# Altium schematic sheet 6-Latching AMS.SchDoc("6-Latching AMS")
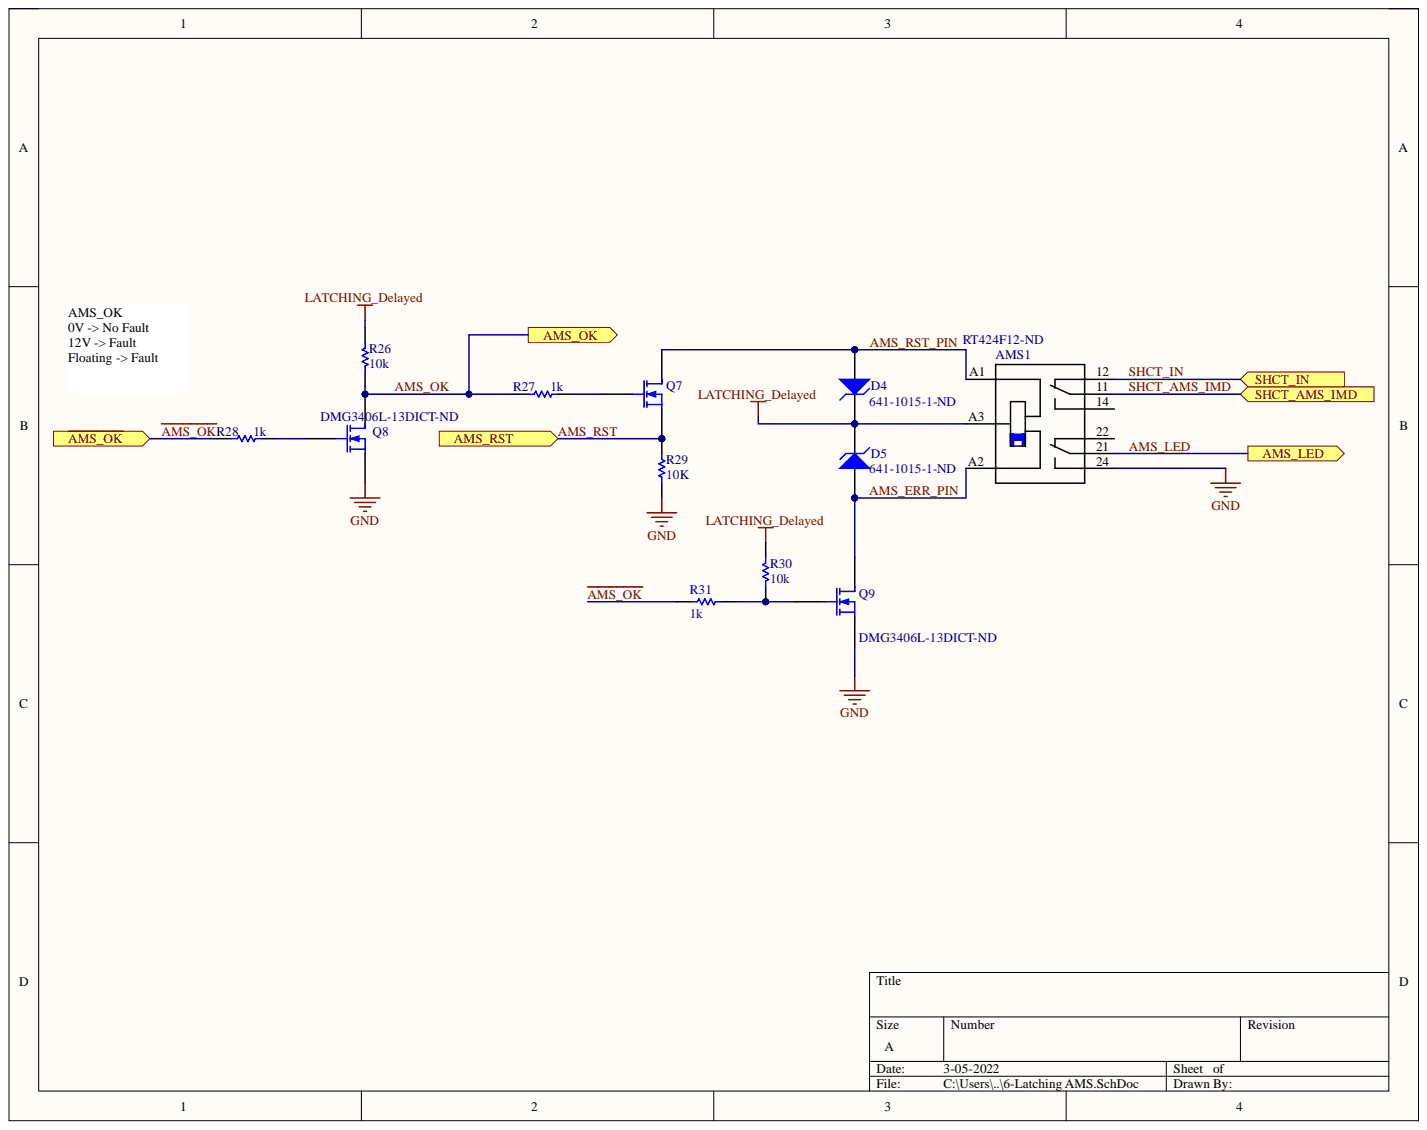
[diagram p0: 6-Latching AMS.SchDoc]

## Parts
AMS1  Supplier Part Number 1: RT424F12-ND  [TE_5-1393243-4]  (hidden comment: 5-1393243-4)
D4  Supplier Part Number 1: 641-1015-1-ND  [DO214AC]  (hidden comment: D Zener)
D5  Supplier Part Number 1: 641-1015-1-ND  [DO214AC]  (hidden comment: D Zener)
Q7  (no value)  [SOT-23_N]
Q8  Supplier Part Number 1: DMG3406L-13DICT-ND  [SOT-23_N]
Q9  Supplier Part Number 1: DMG3406L-13DICT-ND  [SOT-23_N]
R26  Resistance (Ohms): 10k  [1608[0603]]  (hidden comment: RHM1.0KDCT-ND)
R27  value 1k  [1608[0603]]  (hidden comment: Res1)
R28  value 1k  [1608[0603]]  (hidden comment: Res1)
R29  value 10K  [1608[0603]]  (hidden comment: Res1)
R30  Resistance (Ohms): 10k  [1608[0603]]  (hidden comment: RHM1.0KDCT-ND)
R31  1k  [1608[0603]]

## Ports
AMS_LED, AMS_OK, AMS_RST, SHCT_AMS_IMD, SHCT_IN, ~{AMS_OK}

## Nets
GND: AMS1.24 Q8.2 Q9.2 R29.1
LATCHING_Delayed: AMS1.A3 D4.2 D5.2 R26.1 R30.1
~{AMS_OK}: R28.1 R31.1 ; port ~{AMS_OK}
AMS_ERR_PIN: AMS1.A2 D5.1 Q9.3
AMS_LED: AMS1.21 ; port AMS_LED
AMS_OK: Q8.3 R26.2 R27.1 ; port AMS_OK
AMS_RST: Q7.2 R29.2 ; port AMS_RST
AMS_RST_PIN: AMS1.A1 D4.1 Q7.3
SHCT_AMS_IMD: AMS1.11 ; port SHCT_AMS_IMD
SHCT_IN: AMS1.12 ; port SHCT_IN
NetQ7_1: Q7.1 R27.2
NetQ8_1: Q8.1 R28.2
NetQ9_1: Q9.1 R30.2 R31.2

Not connected: AMS1 14 22

## Library data of the parts
AMS1 = 5-1393243-4: Electromechanical Relay 12VDC 240Ohm 15A DPDT __ 29mm 12.7mm 15.7mm__ THT Power Relay; MF: TE Connectivity; Component Kind: Standard; Library Name: 5-1393243-4.IntLib; MP: 5-1393243-4; Package: None; SnapEDA_Link: https://www.snapeda.com/parts/5-1393243-4/TE%20Connectivity/view-part/?ref=snap; Supplier 1: Digi-Key; TE_purchase_URL: https://www.te.com/usa-en/product-5-1393243-4.html?te_bu=Cor&te_type=disp&te_campaign=seda_glo_cor-seda-global-disp-prtnr-fy19-seda-model-bom-cta_sma-317_1&elqCampaignId=32493
D4 D5 = D Zener: Zener Diode; Component Kind: Standard; LatestRevisionDate: 17-Jul-2002; LatestRevisionNote: Re-released for DXP Platform.; Library Name: Miscellaneous Devices.IntLib; PackageDescription: Diode, Thru-Hole; 2 Leads; 0.7 in Pin Spacing; PackageReference: DIODE-0.7; Published: 8-Jun-2000; Signal Integrity: D Zener; Simulation: ZENER; Supplier 1: Digi-Key; Publisher: Altium Limited
Q7-Q9 = MOSFET-N: N-Channel MOSFET; Manufacturer: Infineon Technologies; Current - Continuous Drain (Id) @ 25°C: 50A (Tc); Drain to Source Voltage (Vdss): 30V; FET Feature: Logic Level Gate; FET Type: MOSFET N-Channel, Metal Oxide; Gate Charge (Qg) @ Vgs: 18nC @ 10V; Input Capacitance (Ciss) @ Vds: 1900pF @ 15V; Power - Max: 47W; Rds On (Max) @ Id, Vgs: 7.5 mOhm @ 30A, 10V; Vgs(th) (Max) @ Id: 2.2V @ 250µA; Category: Discrete Semiconductor Products; Component Kind: Standard; ComponentLink1Description: http://www.infineon.com/dgdl/Infineon-IPD075N03LG-DS-v02_01-en.pdf?folderId=5546d4694909da4801490a07012f053b&fileId=db3a30431936bc4b011951d824f83aeb; ComponentLink1URL: http://www.infineon.com/dgdl/Infineon-IPD075N03LG-DS-v02_01-en.pdf?folderId=5546d4694909da4801490a07012f053b&fileId=db3a30431936bc4b011951d824f83aeb; ComponentLink2Description: http://www.semicon.toshiba.co.jp/info/docget.jsp?type=datasheet&lang=en&pid=SSM3K329R; ComponentLink2URL: http://www.semicon.toshiba.co.jp/info/docget.jsp?type=datasheet&lang=en&pid=SSM3K329R; LatestRevisionDate: 17-Jul-2002; LatestRevisionNote: Re-released for DXP Platform.; Library Name: Miscellaneous Devices.IntLib; Mounting Type: Surface Mount; Package / Case: TO-252-3, DPak (2 Leads + Tab), SC-63; PackageDescription: TO, Flat Index; 3 In-Line, Axial Leads; Body Dia. 4.6mm; Lead Dia. 0.5 mm (max); PackageReference: E3; PackageVersion: 1995/1996; Packaging: Cut Tape (CT); Published: 8-Jun-2000; RoHS: RoHS Compliant; Simulation: NMOS; Supplier 1: Digi-Key; Supplier Device Package: PG-TO252-3; Publisher: Altium Limited
  Q7: Supplier Part Number 1: DMG3406L-13DICT-ND
R26 R30 = Res1: RES SMD 1.0K OHM 5% 1/4W 0603; Manufacturer: Rohm Semiconductor; Manufacturer Part Number: ESR03EZPJ102; Power (Watts): 0.25W, 1/4W; Temperature Coefficient: ±200ppm/°C; Category: Resistors; Component Kind: Standard; ComponentLink1Description: http://rohmfs.rohm.com/en/products/databook/datasheet/passive/resistor/chip_resistor/esr.pdf; ComponentLink1URL: http://rohmfs.rohm.com/en/products/databook/datasheet/passive/resistor/chip_resistor/esr.pdf; Composition: Thick Film; Failure Rate: -; Features: Automotive AEC-Q200, Pulse Withstanding; Height - Seated (Max): 0.022" (0.55mm); Library Name: Miscellaneous Devices.IntLib; Operating Temperature: -55°C ~ 155°C; Package / Case: 0603 (1608 Metric); Packaging: Cut Tape (CT); Part Status: Active; Pricing 1: 1=0.15, 10=0.119, 25=0.0824, 50=0.0642, 100=0.0458, 250=0.03568, 500=0.02836, 1000=0.02012 (CAD); RoHS: RoHS Compliant; Signal Integrity: Res; Simulation: RESISTOR; Size / Dimension: 0.063" L x 0.031" W (1.60mm x 0.80mm); Stock 1: 220; Supplier 1: Digi-Key; Supplier Device Package: 0603; Supplier Part Number 1: RHM1.0KDCT-ND; Tolerance: ±5%
R27 R28 = Res1: RES SMD 100 OHM 5% 1/4W 0603; Manufacturer: Rohm Semiconductor; Manufacturer Part Number: ESR03EZPJ101; Power (Watts): 0.25W, 1/4W; Resistance (Ohms): 100; Temperature Coefficient: ±200ppm/°C; Category: Resistors; Component Kind: Standard; ComponentLink1Description: http://rohmfs.rohm.com/en/products/databook/datasheet/passive/resistor/chip_resistor/esr.pdf; ComponentLink1URL: http://rohmfs.rohm.com/en/products/databook/datasheet/passive/resistor/chip_resistor/esr.pdf; Composition: Thick Film; Failure Rate: -; Features: Automotive AEC-Q200, Pulse Withstanding; Height - Seated (Max): 0.022" (0.55mm); LatestRevisionDate: 17-Jul-2002; LatestRevisionNote: Re-released for DXP Platform.; Library Name: Miscellaneous Devices.IntLib; Operating Temperature: -55°C ~ 155°C; Package / Case: 0603 (1608 Metric); PackageDescription: Axial Device, Thru-Hole; 2 Leads; 0.3 in Pin Spacing; PackageReference: AXIAL-0.3; Packaging: Cut Tape (CT); Part Status: Active; Pricing 1: 1=0.15, 10=0.119, 25=0.0824, 50=0.0642, 100=0.0458, 250=0.03568, 500=0.02836, 1000=0.02012 (CAD); Published: 8-Jun-2000; RoHS: RoHS Compliant; Signal Integrity: Res; Simulation: RESISTOR; Size / Dimension: 0.063" L x 0.031" W (1.60mm x 0.80mm); Stock 1: 57430; Supplier 1: Digi-Key; Supplier Device Package: 0603; Supplier Part Number 1: RHM100DCT-ND; Tolerance: ±5%; Publisher: Altium Limited
R29 = Res1: RES SMD 10K OHM 5% 1/4W 0603; Manufacturer: Rohm Semiconductor; Manufacturer Part Number: ESR03EZPJ103; Power (Watts): 0.25W, 1/4W; Temperature Coefficient: ±200ppm/°C; Category: Resistors; Component Kind: Standard; ComponentLink1Description: http://rohmfs.rohm.com/en/products/databook/datasheet/passive/resistor/chip_resistor/esr.pdf; ComponentLink1URL: http://rohmfs.rohm.com/en/products/databook/datasheet/passive/resistor/chip_resistor/esr.pdf; Composition: Thick Film; Failure Rate: -; Features: Automotive AEC-Q200, Pulse Withstanding; Height: 0.022" (0.55mm); Height - Seated (Max): 0.022" (0.55mm); LatestRevisionDate: 17-Jul-2002; LatestRevisionNote: Re-released for DXP Platform.; Library Name: Miscellaneous Devices.IntLib; Number of Terminations: 2; Operating Temperature: -55°C ~ 155°C; Package / Case: 0603 (1608 Metric); PackageDescription: Axial Device, Thru-Hole; 2 Leads; 0.3 in Pin Spacing; PackageReference: AXIAL-0.3; Packaging: Cut Tape (CT); Part Status: Active; Pricing 1: 1=0.15, 10=0.119, 25=0.0824, 50=0.0642, 100=0.0458, 250=0.03568, 500=0.02836, 1000=0.02012 (CAD); Published: 8-Jun-2000; Resistance (Ohms): 10k; RoHS: RoHS Compliant; Signal Integrity: Res; Simulation: RESISTOR; Size / Dimension: 0.063" L x 0.031" W (1.60mm x 0.80mm); Stock 1: 0; Supplier 1: Digi-Key; Supplier 2: Digi-Key; Supplier Device Package: 0603 (1608 Metric); Supplier Part Number 1: RHM10KDCT-ND; Supplier Part Number 2: 311-10KGRCT-ND; Tolerance: ±5%; Publisher: Altium Limited
R31 = Res1: Resistor; Manufacturer: Panasonic Electronic Components; Manufacturer Part Number: ERJ-3EKF60R4V; Power (Watts): 0.1W, 1/10W; Resistance (Ohms): 60.4; Temperature Coefficient: ±100ppm/°C; Tolerance: ±1%; Value: 120; Category: Resistors; Component Kind: Standard; ComponentLink1Description: http://industrial.panasonic.com/www-cgi/jvcr13pz.cgi?E+PZ+3+AOA0002+ERJ3EKF60R4V+7+WW; ComponentLink1URL: http://industrial.panasonic.com/www-cgi/jvcr13pz.cgi?E+PZ+3+AOA0002+ERJ3EKF60R4V+7+WW; Composition: Thick Film; Features: Automotive AEC-Q200; Height: 0.022" (0.55mm); LatestRevisionDate: 17-Jul-2002; LatestRevisionNote: Re-released for DXP Platform.; Library Name: Miscellaneous Devices.IntLib; Number of Terminations: 2; Operating Temperature: -55°C ~ 155°C; Package / Case: 0603 (1608 Metric); PackageDescription: Axial Device, Thru-Hole; 2 Leads; 0.3 in Pin Spacing; PackageReference: AXIAL-0.3; Packaging: Cut Tape (CT); Pricing 1: 1=0.15, 50=0.0176, 100=0.0129, 250=0.00992, 500=0.0079, 1000=0.00583 (CAD); Published: 8-Jun-2000; RoHS: RoHS Compliant; Signal Integrity: Res; Simulation: RESISTOR; Size / Dimension: 0.063" L x 0.031" W (1.60mm x 0.80mm); Stock 1: 113077; Supplier 1: Digi-Key; Supplier Device Package: 0603; Supplier Part Number 1: P60.4HCT-ND; Publisher: Altium Limited
